# Altium schematic sheet [07] - MICRO SD_CARD.SchDoc
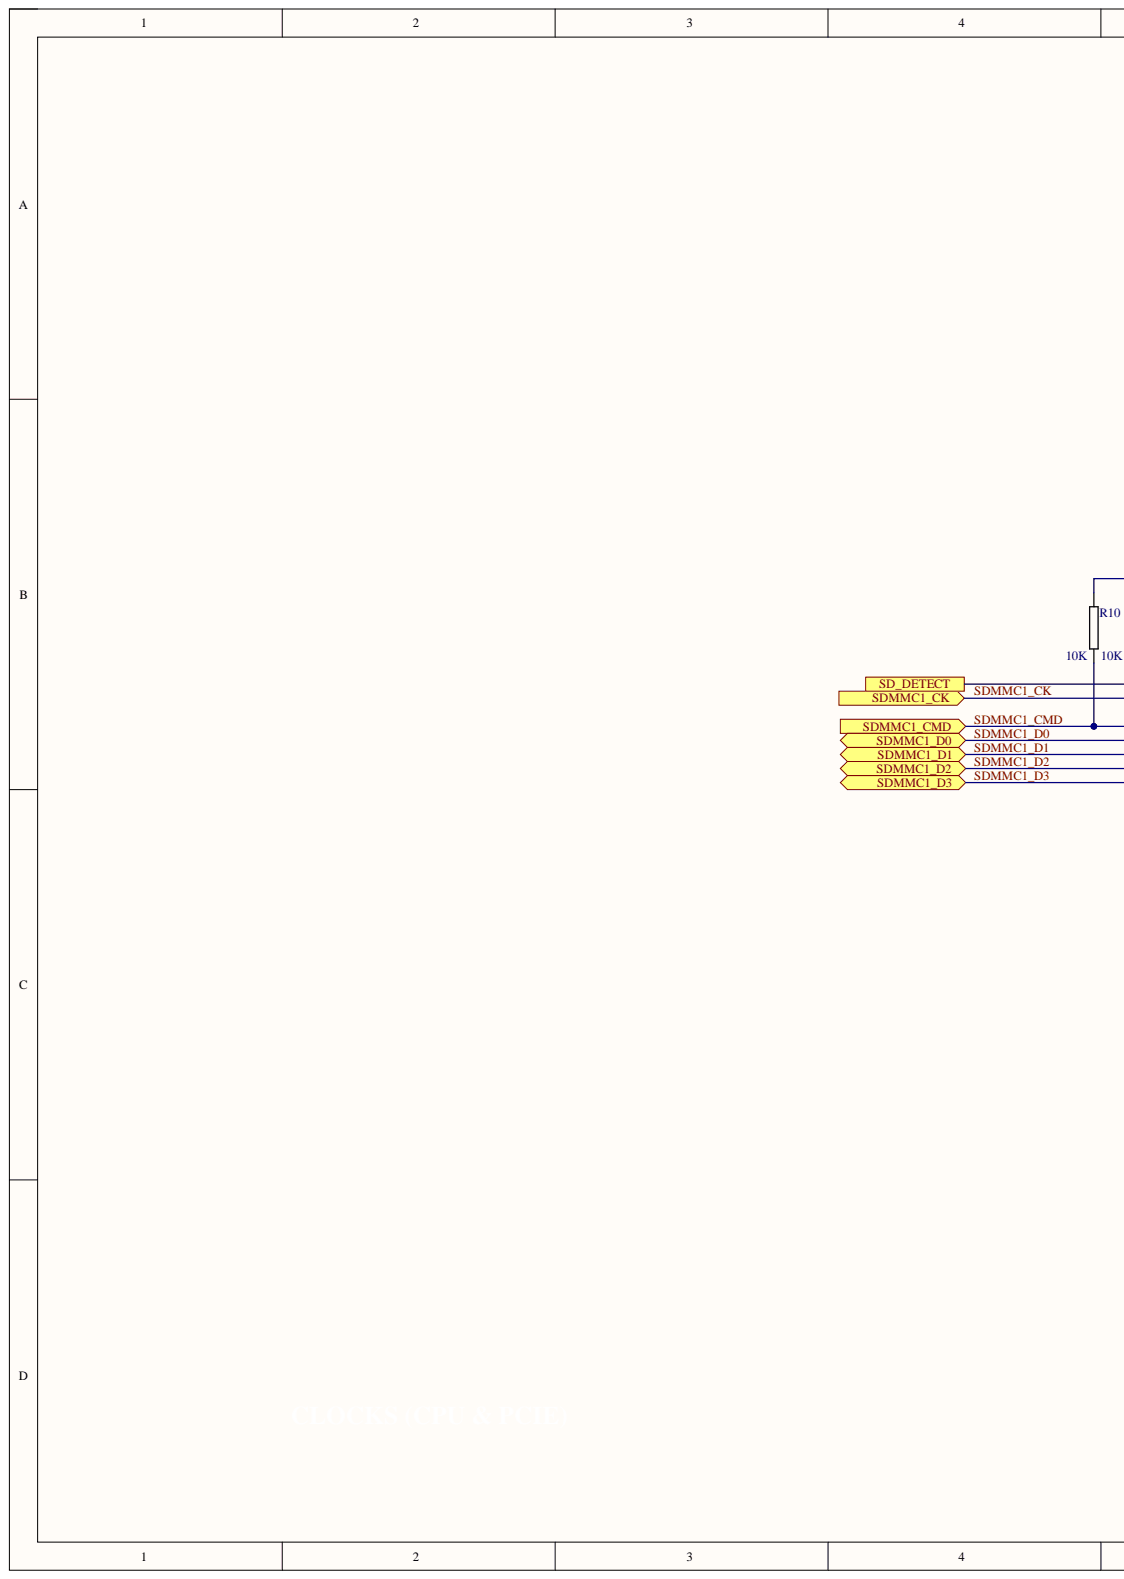
[diagram p0: [07] - MICRO SD_CARD.SchDoc, left]
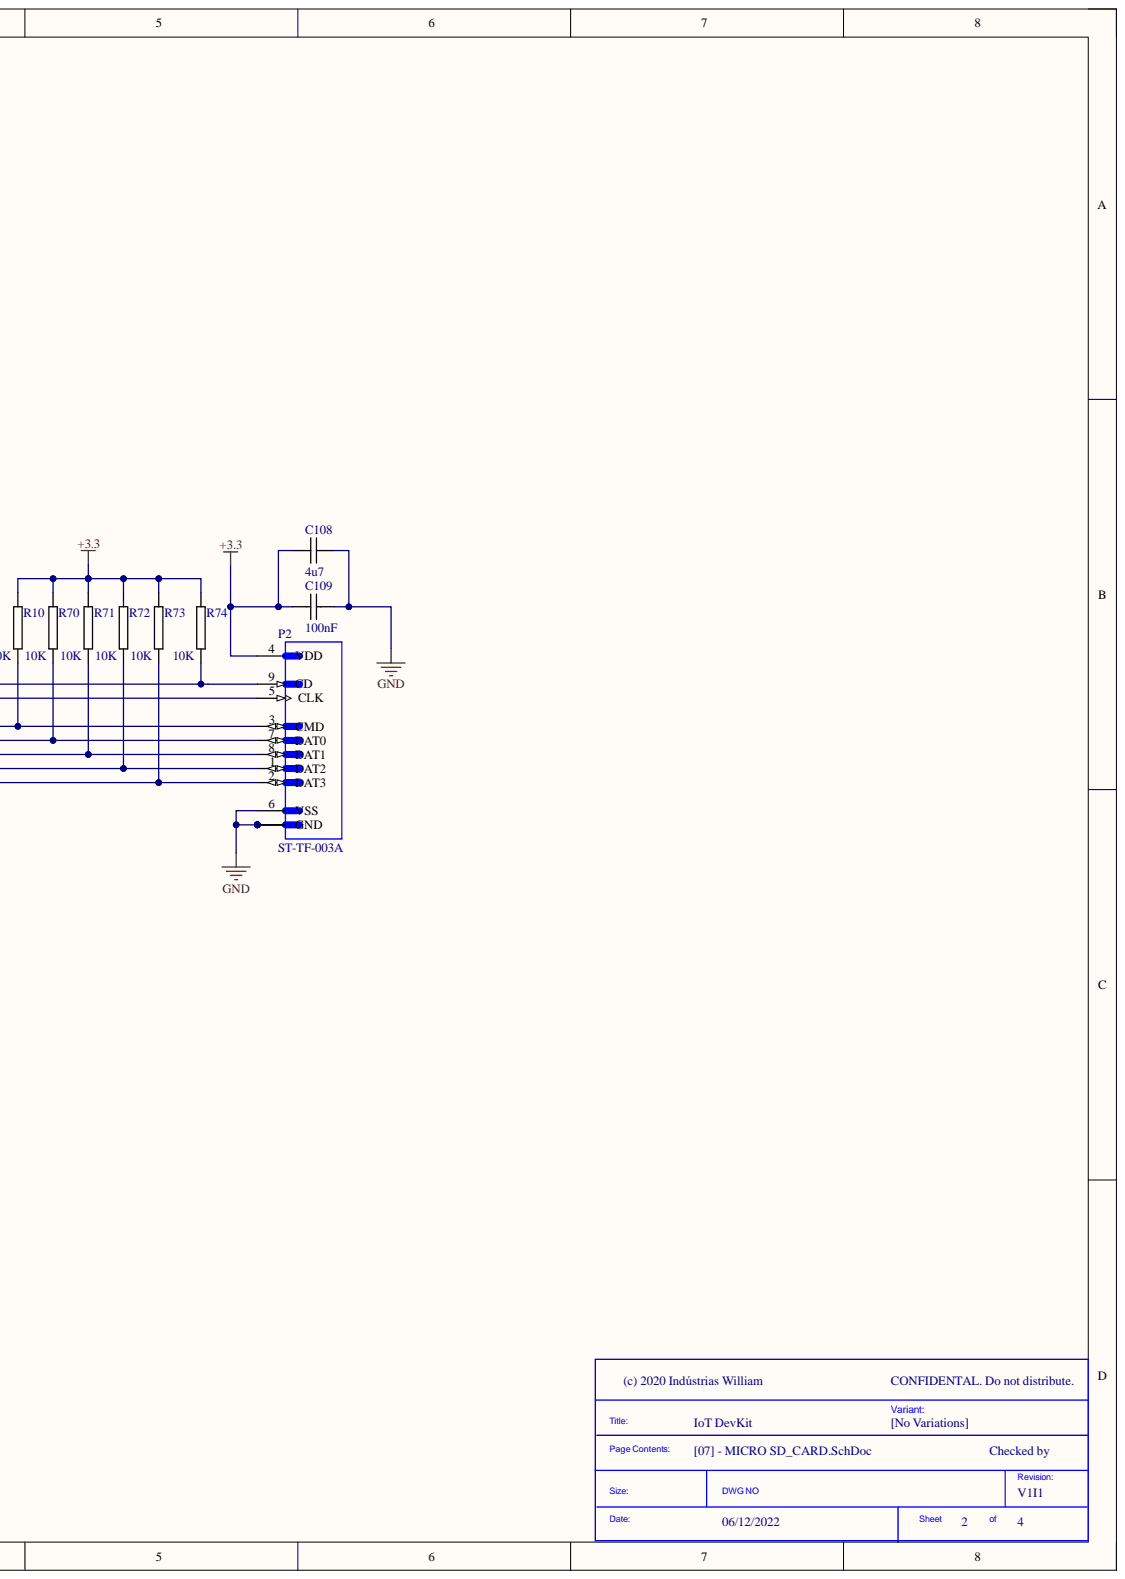
[diagram p1: [07] - MICRO SD_CARD.SchDoc, right]

## Parts
C108  4u7  [0603C-GENERIC]
C109  100nF  [0603C-GENERIC]
P2  ST-TF-003A  [SUNTECH_ST-TF-003A]
R10  10K  [0603R-10K]
R70  10K  [0603R-10K]
R71  10K  [0603R-10K]
R72  10K  [0603R-10K]
R73  10K  [0603R-10K]
R74  10K  [0603R-10K]

## Ports
SD_DETECT, SDMMC1_CK, SDMMC1_CMD, SDMMC1_D0, SDMMC1_D1, SDMMC1_D2, SDMMC1_D3

## Nets
+3.3: C108.1 C109.1 P2.4(VDD) R10.1 R70.1 R71.1 R72.1 R73.1 R74.1
GND: C108.2 C109.2 P2.6(VSS) P2.G1(GND) P2.G2 P2.G3 P2.G4
SD_DETECT: P2.9(CD) R74.2 ; port SD_DETECT
SDMMC1_CK: P2.5(CLK) ; port SDMMC1_CK
SDMMC1_CMD: P2.3(CMD) R10.2 ; port SDMMC1_CMD
SDMMC1_D0: P2.7(DAT0) R70.2 ; port SDMMC1_D0
SDMMC1_D1: P2.8(DAT1) R71.2 ; port SDMMC1_D1
SDMMC1_D2: P2.1(DAT2) R72.2 ; port SDMMC1_D2
SDMMC1_D3: P2.2(DAT3) R73.2 ; port SDMMC1_D3

## Library data of the parts
C108 = 0603C-4U7-10V-X5R-SAM: CAPACITOR 0603 CERAMIC; Reel: C46; Component Kind: Standard; Library Name: vh_altium_library.intLib
C109 = 0603C-100NF-25V-X7R-TDK: CAPACITOR 0603 CERAMIC; Manufacturer: TDK Corporation; Manufacturer Part Number: C1608X7R1E104K; Reel: C12; Component Kind: Standard; Library Name: vh_altium_library.intLib; Supplier 1: Digi-Key; Supplier Part Number 1: 445-1316-2-ND
P2 = ST-TF-003A: Micro SD Card Socket; MF: Suntech; Availability: Unavailable; Component Kind: Standard; MP: ST-TF-003A; Package: None; Price: None; SnapEDA_Link: https://www.snapeda.com/parts/ST-TF-003A/Suntech/view-part/214778/?ref=snap; Library Name: Componentes Deived Projetos.IntLib
R10 R70-R74 = 0603R-10K: GENERIC RESISTOR 0603; Manufacturer: Stackpole Electronics Inc; Manufacturer Part Number: RMCF 1/16 10K 1% R; Power (Watts): 0.1W, 1/10W; Reel: R21; Resistance In Ohms: 10.0K; Temperature Coefficient: ±100ppm/°C; Tolerance: ±1%; Case: 0603 (1608 metric); Category: Resistors; Component Kind: Standard; Composition: Thick Film; Lead Style: Surface Mount (SMD - SMT); Library Name: vh_altium_library.intLib; Packaging: Digi-Reel®; RoHS: RoHS Compliant; Supplier: Digi-Key; Supplier 1: Digi-Key; Supplier Part Number: RMCF1/1610KFRDKR-ND; Supplier Part Number 1: RMCF0603FT10K0DKR-ND
